# Altium schematic sheet mbedXdotShield-V_1_2_0_2.SchDoc(mbedXdotShield-V_1_2_0_2)
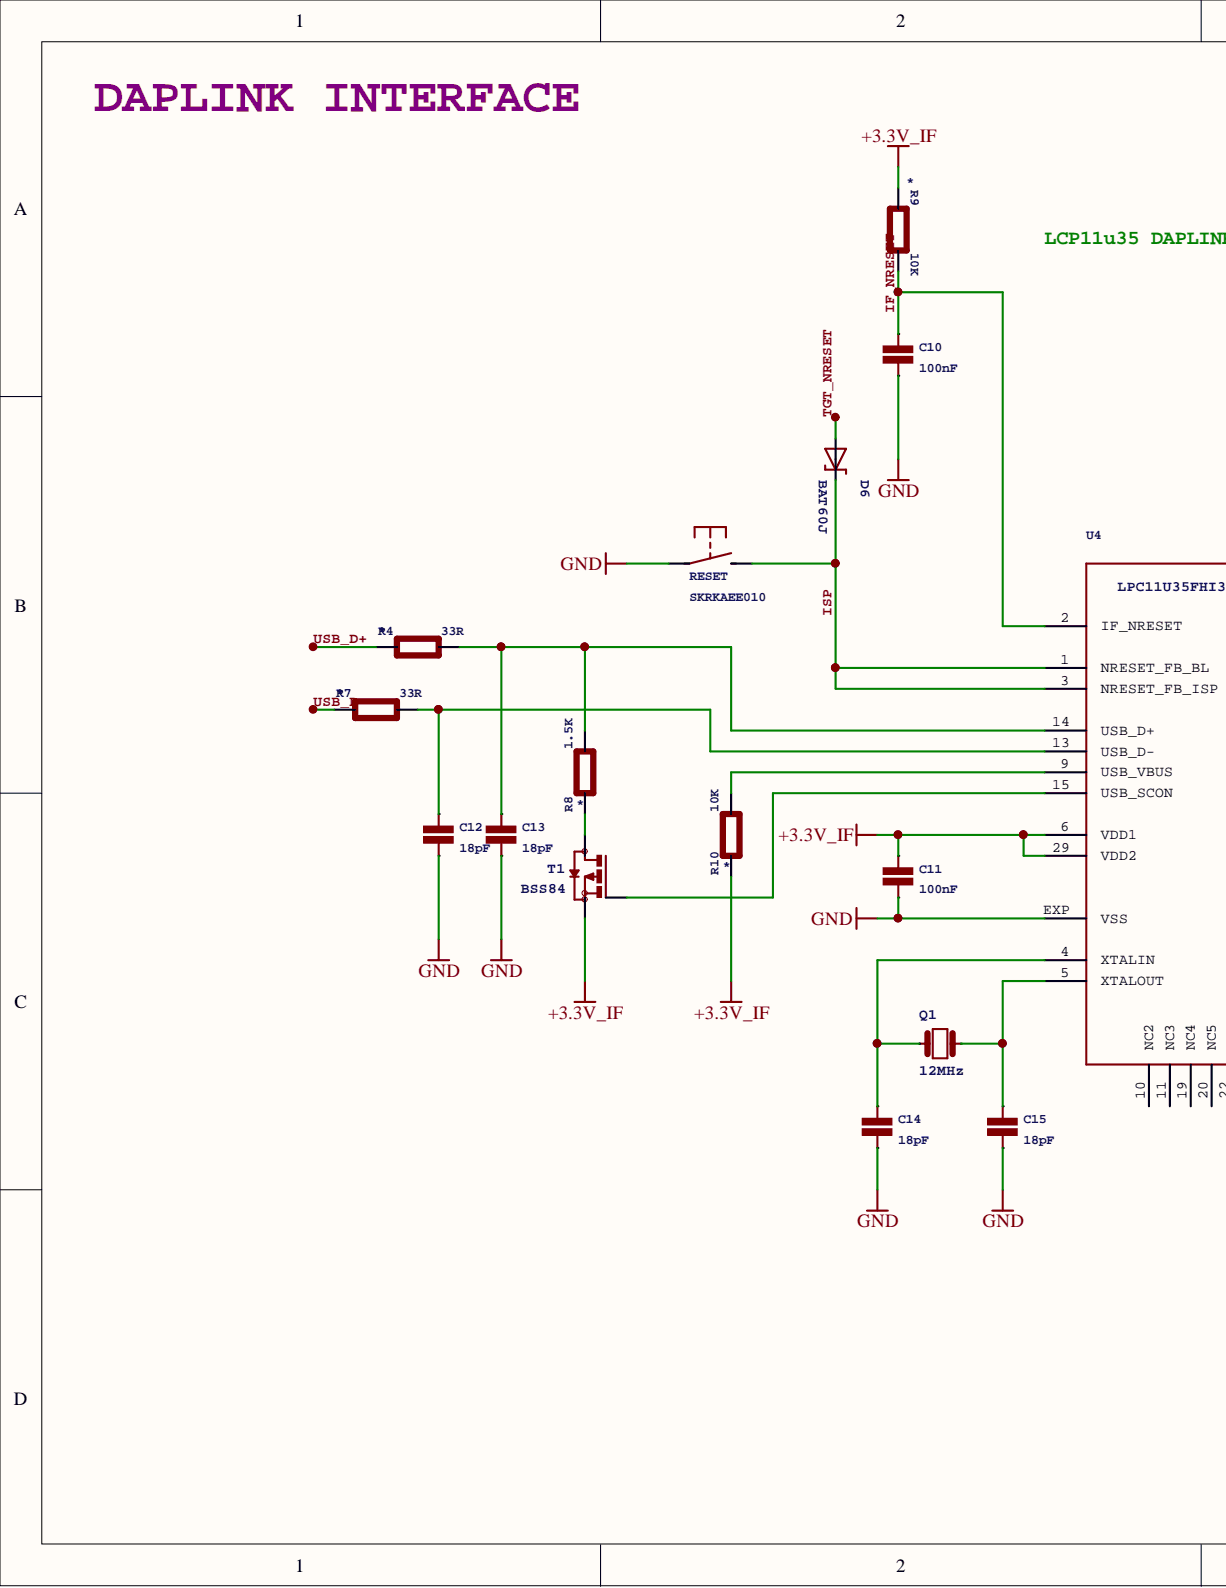
[diagram p0: mbedXdotShield-V_1_2_0_2.SchDoc, left]
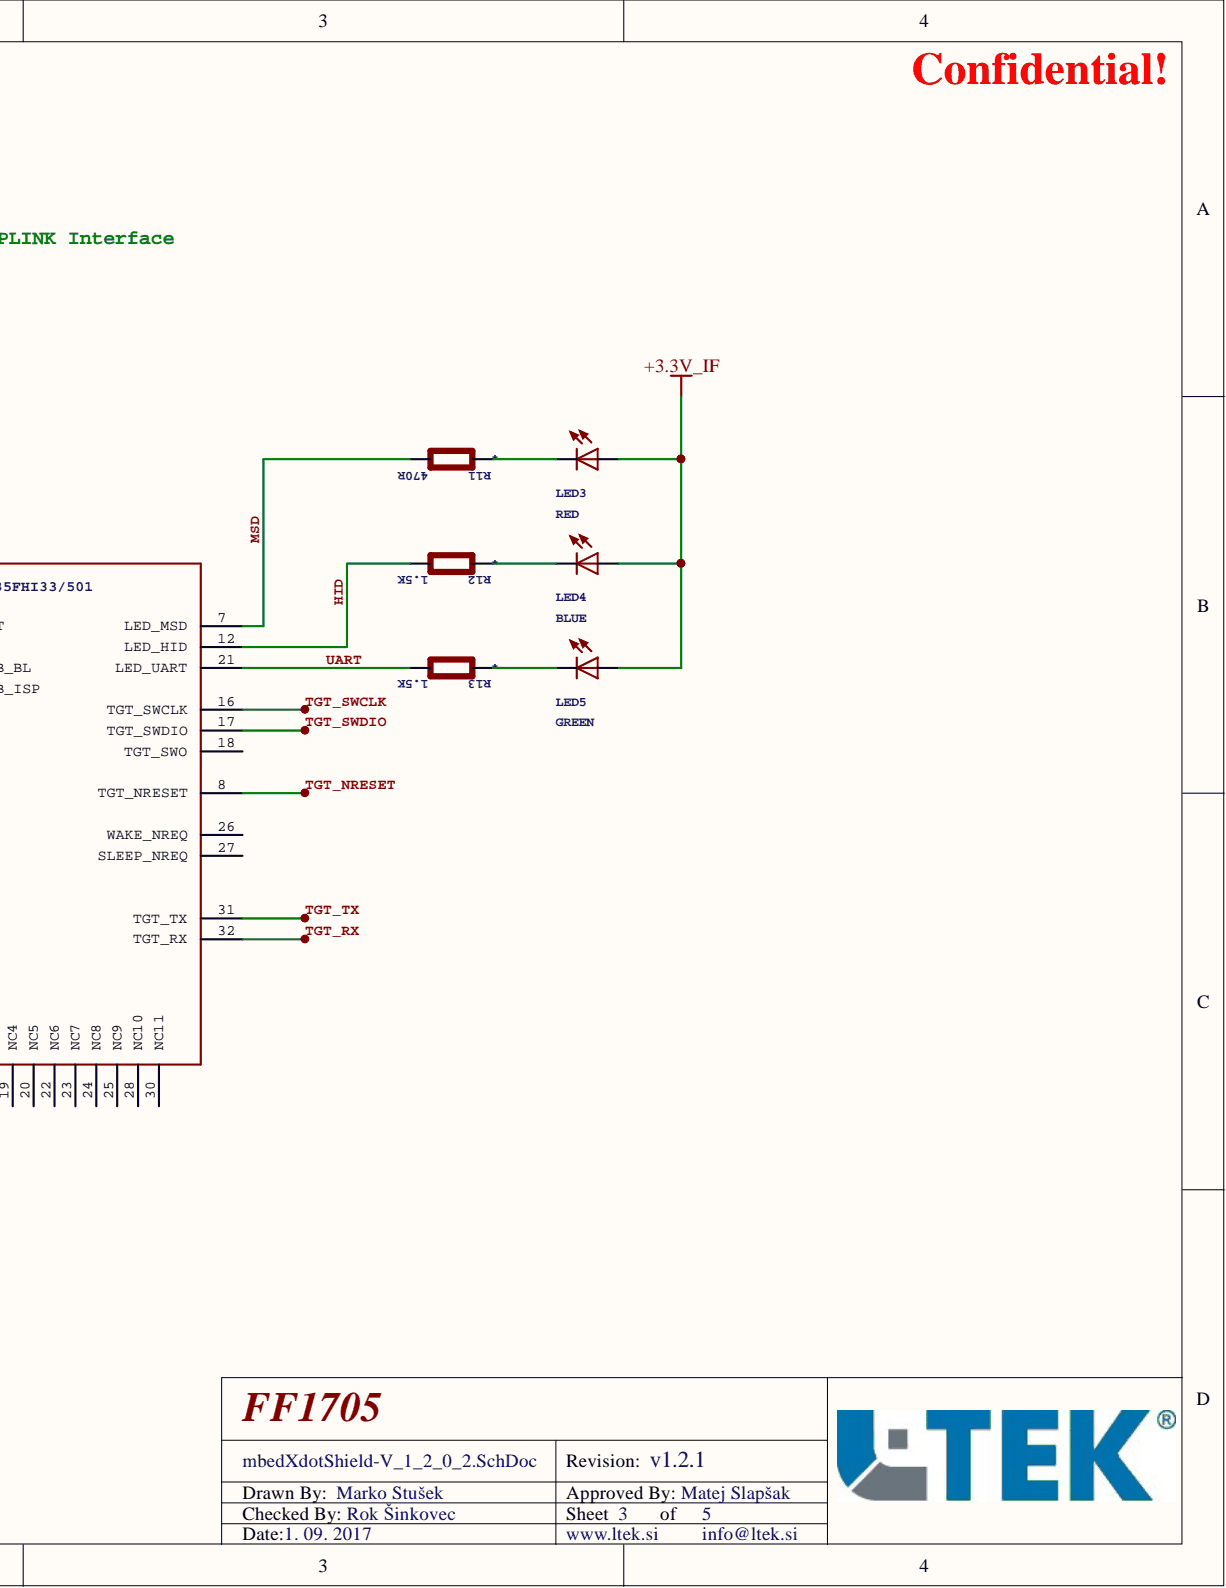
[diagram p1: mbedXdotShield-V_1_2_0_2.SchDoc, right]

## Parts
C10  100nF  [0402]
C11  100nF  [0402]
C12  18pF  [0402]
C13  18pF  [0402]
C14  18pF  [0402]
C15  18pF  [0402]
D6  BAT60J  [SOD323]
LED3  RED  [CHIPLED_0603]
LED4  BLUE  [CHIPLED_0603]
LED5  GREEN  [CHIPLED_0603]
Q1  12MHz  [3.2X.2.5]
R4  33R  [0402]
R7  33R  [0402]
R8  1.5K  [0402]
R9  10K  [0402]
R10  10K  [0402]
R11  470R  [0402]
R12  1.5K  [0402]
R13  1.5K  [0402]
RESET  SKRKAEE010  [SKRKAEE010]
T1  BSS84  [SOT23-3]
U4  IF-LPC11U35  [HVQFN33]  (value not drawn)

## Nets
+3.3V_IF: C11.1 LED3.A LED4.A LED5.A R9.1 R10.1 T1.2 U4.6(VDD1) U4.29(VDD2)
GND: C10.2 C11.2 C12.2 C13.2 C14.2 C15.2 RESET.P$1 U4.EXP(VSS)
HID: R12.2 U4.12(LED_HID)
IF_NRESET: C10.1 R9.2 U4.2(IF_NRESET)
ISP: D6.K RESET.P$2 U4.1(NRESET_FB_BL) U4.3(NRESET_FB_ISP)
MSD: R11.2 U4.7(LED_MSD)
TGT_NRESET: D6.A U4.8(TGT_NRESET)
TGT_RX: U4.32(TGT_RX)
TGT_SWCLK: U4.16(TGT_SWCLK)
TGT_SWDIO: U4.17(TGT_SWDIO)
TGT_TX: U4.31(TGT_TX)
UART: R13.2 U4.21(LED_UART)
USB_D+: R4.1
USB_D-: R7.1
NetC12_1: C12.1 R7.2 U4.13(USB_D-)
NetC13_1: C13.1 R4.2 R8.2 U4.14(USB_D+)
NetC14_1: C14.1 Q1.P$1 U4.4(XTALIN)
NetC15_1: C15.1 Q1.P$3 U4.5(XTALOUT)
NetLED3_C: LED3.C R11.1
NetLED4_C: LED4.C R12.1
NetLED5_C: LED5.C R13.1
NetR8_1: R8.1 T1.3
NetR10_2: R10.2 U4.9(USB_VBUS)
NetT1_1: T1.1 U4.15(USB_SCON)

Not connected: U4 10(NC2) 11(NC3) 18(TGT_SWO) 19(NC4) 20(NC5) 22(NC6) 23(NC7) 24(NC8) 25(NC9) 26(WAKE_NREQ) 27(SLEEP_NREQ) 28(NC10) 30(NC11)

## Library data of the parts
C10-C15 = CAPACITOR0402: Capacitor; Component Kind: Standard; Library Name: mbed-HDK-RLCQ.IntLib
D6 = BAT60J: SCHOTTKY DIODE; MFN: BAT60JFILM; MF: STMicroelectronics; OC-NEWARK: 89K1218; OC-MOUSER: 511-BAT60JFILM; OC-DIGIKEY: 497-3707-6-ND; Component Kind: Standard; Library Name: mbed-HDK-Transistor.IntLib
LED3-LED5 = SMD_LED0603: LED SMD, Colour Red; MFN: 150060RS75000; MF: Wurth Electronics Inc; OC-NEWARK: 2322071; OC-MOUSER: 710-150060RS75000; OC-DIGIKEY: 732-4978-1-ND; Component Kind: Standard; Library Name: mbed-HDK-Optical.IntLib
Q1 = Q_3.2X2.5: Component Kind: Standard; Library Name: mbed-HDK.IntLib
R4 R7-R13 = RESISTOR0402: Resistor; Component Kind: Standard; Library Name: mbed-HDK-RLCQ.IntLib
RESET = SKRKAEE010: MOMENTARY SWITCH PUSH VERTICAL (SPST); MF: ALPS; OC-MOUSER: 688-SKRKAE; MFN: SKRKAEE010; Component Kind: Standard; Library Name: mbed-HDK-Switch.IntLib
T1 = BSS84: MOSFET P-CHANNEL; MF: Fairchild Semiconductor; OC-NEWARK: 58K8770; OC-MOUSER: 512-BSS84; OC-DIGIKEY: BSS84CT-ND; MFN: BSS84; Component Kind: Standard; Library Name: mbed-HDK-Transistor.IntLib
U4 = IF-LPC11U35: LPC11U35FHI33/501: LPC11U35FHI33/501; Component Kind: Standard; Library Name: mbed-HDK.IntLib
